# Altium schematic sheet F_EKG.SchDoc
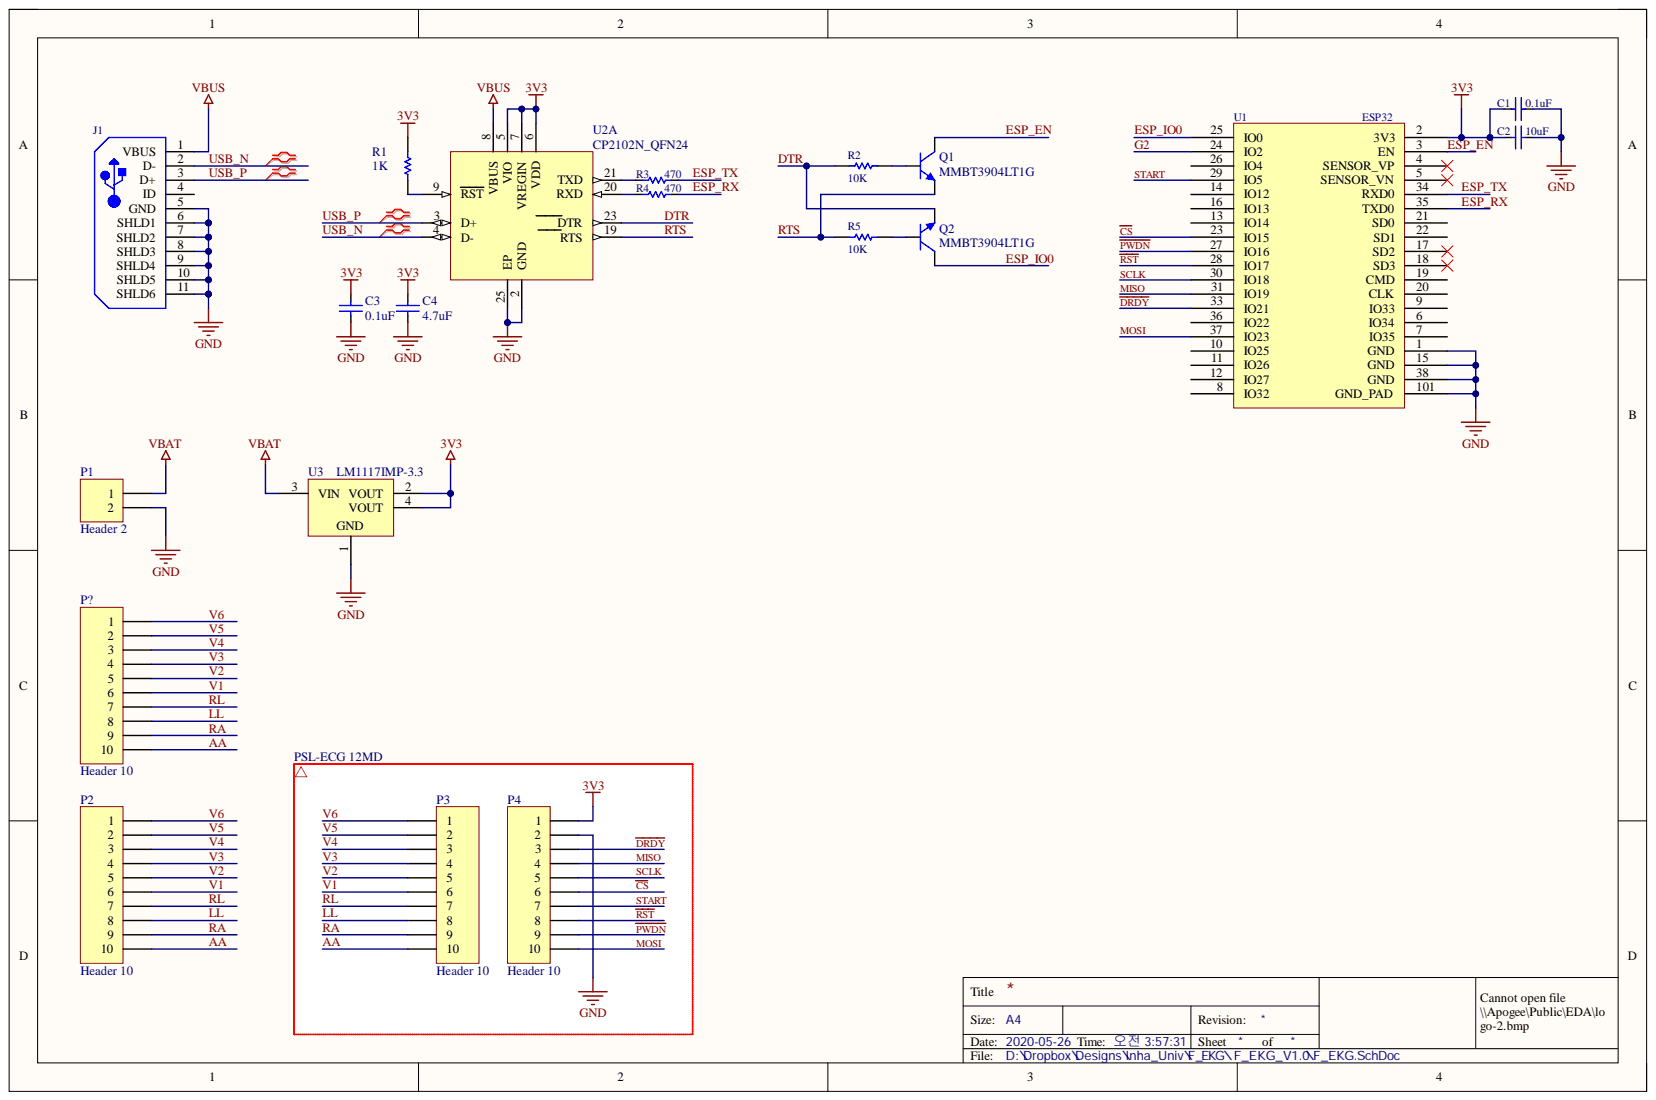
[diagram p0: F_EKG.SchDoc]

## Parts
C1  0.1uF  [CAPC1608X90N_2]
C2  10uF  [CAPC2012X145N]
C3  0.1uF  [CAPC1608X90N_2]
C4  4.7uF  [CAPC1608X90N_2]
J1  10118193-0001LF  [FCI-10118193-0001LF-5_V]  (value not drawn)
P1  Header 2  [HDR1X2]
P2  Header 10  [53261_10]
P3  Header 10  [HDR1X10]
P4  Header 10  [HDR1X10]
P?  Header 10  [53261_10]
Q1  MMBT3904LT1G  [ONSC-SOT-23-3-318-08_V]
Q2  MMBT3904LT1G  [ONSC-SOT-23-3-318-08_V]
R1  1K  [CAPC1608X90N_2]
R2  10K  [CAPC1608X90N_2]
R3  470  [CAPC1608X90N_2]
R4  470  [CAPC1608X90N_2]
R5  10K  [CAPC1608X90N_2]
U1  ESP32  [ESP32S]
U2  CP2102N_QFN24  [SMSC QFN-24 4x4MM]  (part A)
U3  LM1117IMP-3.3  [DCY0004A_M]

## Nets
3V3: C1.1 C2.1 C3.1 C4.1 P4.1 R1.1 U1.2(3V3) U2.5(VIO) U2.6(VDD) U2.7(VREGIN) U3.2(VOUT) U3.4(VOUT)
GND: C1.2 C2.2 C3.2 C4.2 J1.5(GND) J1.6(SHLD1) J1.7(SHLD2) J1.8(SHLD3) J1.9(SHLD4) J1.10(SHLD5) J1.11(SHLD6) P1.2 P4.2 U1.1(GND) U1.15(GND) U1.38(GND) U1.101(GND_PAD) U2.2(GND) U2.25(EP) U3.1
VBAT: P1.1 U3.3(VIN)
VBUS: J1.1(VBUS) U2.8(VBUS)
~{PWDN}: P4.9 U1.27(IO16)
AA: P2.10 P3.10 P?.10
~{CS}: P4.6 U1.23(IO15)
~{DRDY}: P4.3 U1.33(IO21)
DTR: Q2.2 R2.2 U2.23(DTR)
ESP_EN: Q1.3 U1.3(EN)
ESP_IO0: Q2.3 U1.25(IO0)
ESP_RX: R4.1 U1.35(TXD0)
ESP_TX: R3.1 U1.34(RXD0)
G2: U1.24(IO2)
LL: P2.8 P3.8 P?.8
MISO: P4.4 U1.31(IO19)
MOSI: P4.10 U1.37(IO23)
~{RST}: P4.8 U1.28(IO17)
RA: P2.9 P3.9 P?.9
RL: P2.7 P3.7 P?.7
RTS: Q1.2 R5.2 U2.19(RTS)
SCLK: P4.5 U1.30(IO18)
START: P4.7 U1.29(IO5)
USB_N: J1.2(D-) U2.4(D-)
USB_P: J1.3(D+) U2.3(D+)
V1: P2.6 P3.6 P?.6
V2: P2.5 P3.5 P?.5
V3: P2.4 P3.4 P?.4
V4: P2.3 P3.3 P?.3
V5: P2.2 P3.2 P?.2
V6: P2.1 P3.1 P?.1
NetQ1_1: Q1.1 R2.1
NetQ2_1: Q2.1 R5.1
NetR1_2: R1.2 U2.9(RST)
NetR3_2: R3.2 U2.21(TXD)
NetR4_2: R4.2 U2.20(RXD)

Not connected: J1 4(ID); U1 4(SENSOR_VP) 5(SENSOR_VN) 6(IO34) 7(IO35) 8(IO32) 9(IO33) 10(IO25) 11(IO26) 12(IO27) 13(IO14) 14(IO12) 16(IO13) 17(SD2) 18(SD3) 19(CMD) 20(CLK) 21(SD0) 22(SD1) 26(IO4) 36(IO22)

## Library data of the parts
C1-C4 = Cap: Capacitor; Component Kind: Standard; LatestRevisionDate: 17-Jul-2002; LatestRevisionNote: Re-released for DXP Platform.; Library Name: Miscellaneous Devices.IntLib; PackageDescription: Radial Cap, Thru-Hole; 2 Leads; 0.3 in Pin Spacing; PackageReference: RAD-0.3; Published: 8-Jun-2000; Signal Integrity: Cap; Simulation: CAP; Publisher: Altium Limited
  C1 C3: Value: 0.1uF
  C2: Value: 10uF
  C4: Value: 4.7uF
J1 = CMP-2000-05119-1: Micro USB B Type Receptacle, -55 to 85 degC, 5-Pin SMD, RoHS, Tape and Reel; Brand: FCI; Connector Type: MicroUSBTypeBreceptacle; Current Rating: 1.8A; Gender: Female; Insulation Resistance: 1000MOhms; Mounting Angle: Right; Number of Contacts: 5Contact; Color: Black; Component Kind: Standard; Contact Material: CopperAlloy; Contact Plating: Gold; Datasheet URL: http://datasheet.octopart.com/10118193-0001LF-FCI-datasheet-55953155.pdf; Datasheet Version: Rev. C, 05/2015; Factory Pack Quantity: 1200; Flammability Rating: UL94V-0; Library Name: Altium Content Vault; Manufacturer: FCI; Manufacturer URL: http://www.fci.com/; Operating Temperature Range: -55Cto+85C; Packaging: Reel; Product: MicroUSBTypeBConnectors; Product Category: USBConnectors; RoHS: Details; Standard: USB2.0; Termination Style: SMD/SMT
P1 = Header 2: Header, 2-Pin; Component Kind: Standard; LatestRevisionDate: 17-Jul-2002; LatestRevisionNote: Re-released for DXP Platform.; Library Name: Miscellaneous Connectors.IntLib; Signal Integrity: Connector; Publisher: Altium Limited
P2-P4 P? = Header 10: Header, 10-Pin; Component Kind: Standard; LatestRevisionDate: 17-Jul-2002; LatestRevisionNote: Re-released for DXP Platform.; Library Name: Miscellaneous Connectors.IntLib; Signal Integrity: Connector; Publisher: Altium Limited
Q1 Q2 = CMP-013-00007-1: General Purpose Transistor, NPN Silicon, 40 V, 0.2 A, -55 to 150 degC, 3-Pin SOT23, RoHS, Tape and Reel; Manufacturer: ON Semiconductor; Elements: 1; Frequency: 300MHz; hFE Min: 80; Power: 225mW; Vce Max: 300mV; Case/Package: TO-236-3; Component Kind: Standard; Datasheet URL: http://www.onsemi.cn/pub_link/Collateral/MMBT3904LT1-D.PDF; Datasheet Version: Rev. 12, 11/2012; Library Name: Altium Concord Pro on Altium 365; Manufacturer URL: http://www.onsemi.com/; Max Operating Temperature: 150°C; Min Operating Temperature: -55°C; Mounting Technology: SM; Package Description: 3-Pin Small Outline Transistor, Body 2.9 x 1.3 mm, Pitch 0.95 mm; Package Quantity: 3000; Package Reference: SOT-23 (318-08); Package URL: http://www.onsemi.cn/pub_link/Collateral/318-08.PDF; Packaging: TapeandReel; Pins: 3; RoHS Compliant: True
R1-R5 = Res1: Resistor; Component Kind: Standard; LatestRevisionDate: 17-Jul-2002; LatestRevisionNote: Re-released for DXP Platform.; Library Name: Miscellaneous Devices.IntLib; PackageDescription: Axial Device, Thru-Hole; 2 Leads; 0.3 in Pin Spacing; PackageReference: AXIAL-0.3; Published: 8-Jun-2000; Signal Integrity: Res; Simulation: RESISTOR; Publisher: Altium Limited
  R1: Value: 1K
  R2 R5: Value: 10K
  R3 R4: Value: 470
U1 = ESP32S_Module: ESP32-WROOM-32U; Component Kind: Standard; Datasheet: https://espressif.com/sites/default/files/documentation/esp_wroom_32_datasheet_en.pdf; Library Name: ESP32S.SchLib
U2 = CP2102N_QFN24: Single-Chip USB-to-UART Data Transfer; Component Kind: Standard; Library Name: Color_ESP.SchLib
U3 = CMP-019-00021-2: Low-Dropout Linear Regulator, 800 mA, -40 to 125 degC, 4-Pin SOT-223 (DCY), Green (RoHS & no Sb/Br), Tape and Reel; Manufacturer: Texas Instruments; Dropout Voltage: 1.2V; Max Input Voltage: 15V; Max Ouput Current: 800mA; Min Input Voltage: 2.6V; Nominal Output Voltage: 5V; Outputs: 1; Case/Package: SOT-223; Component Kind: Standard; Datasheet URL: http://www.ti.com/lit/ds/symlink/lm1117.pdf; Datasheet Version: SNOS412N, 01/2016; Library Name: Altium Concord Pro on Altium 365; Manufacturer URL: http://www.ti.com/; Max Operating Temperature: 125°C; Min Operating Temperature: -40°C; Mounting Technology: SM; Output Current: 800mA; Output Voltage: 5V; Package Description: 4-Pin Small Outline Transistor, Body 6.5 x 3.5 mm, Pitch 2.3 mm; Package Reference: DCY0004A; Packaging: TapeandReel; Pins: 4; RoHS Compliant: True
